# Altium schematic sheet SolenoidSwitching.SchDoc
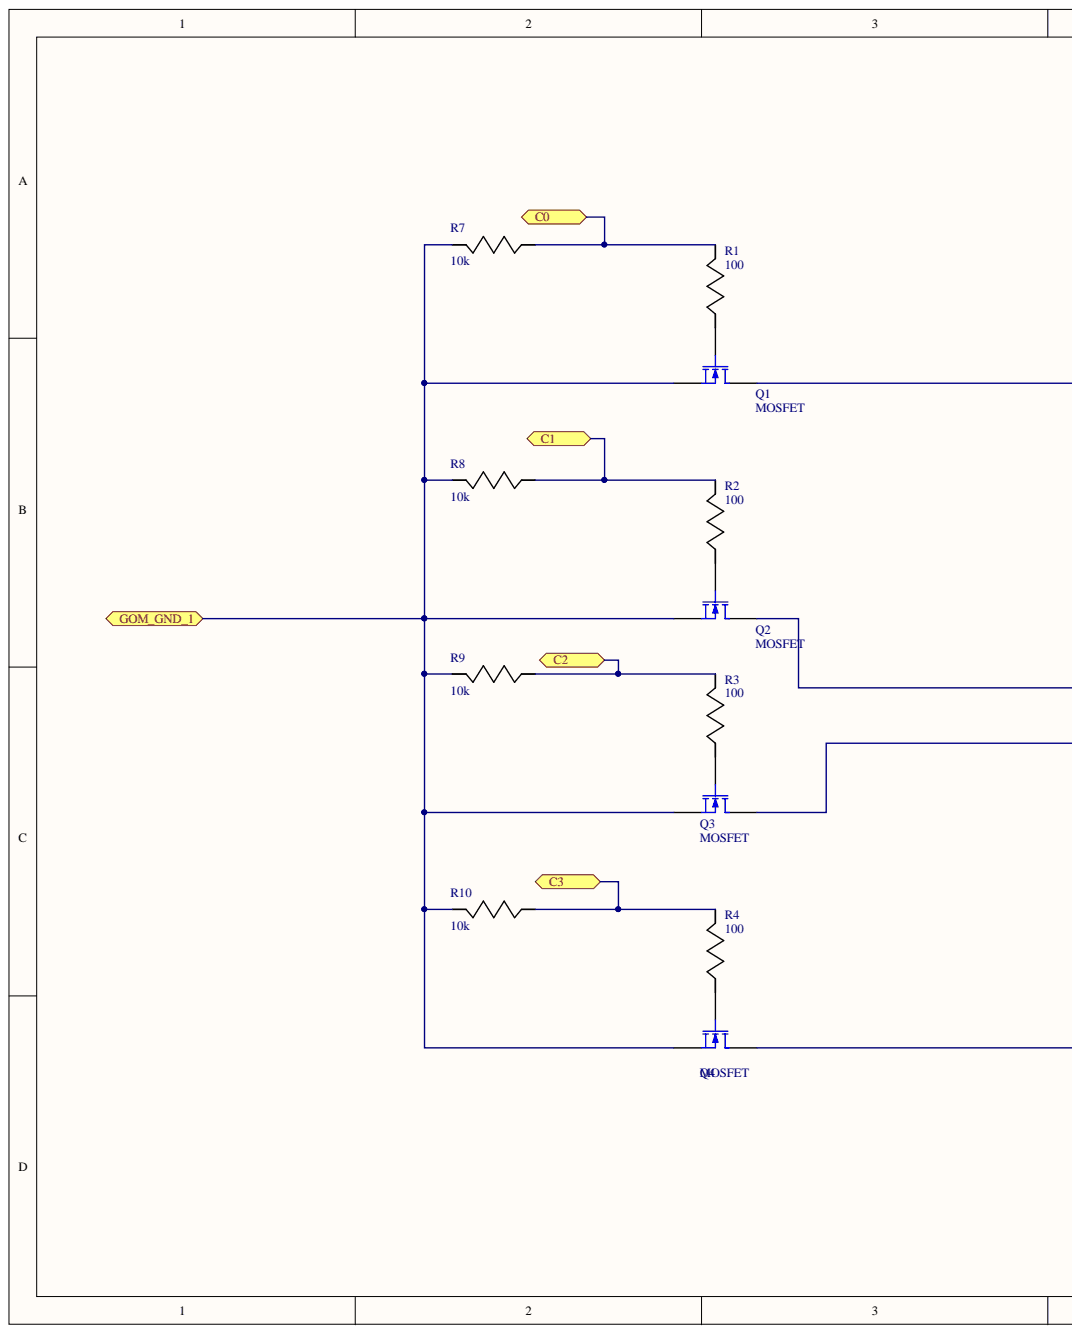
[diagram p0: SolenoidSwitching.SchDoc, left]
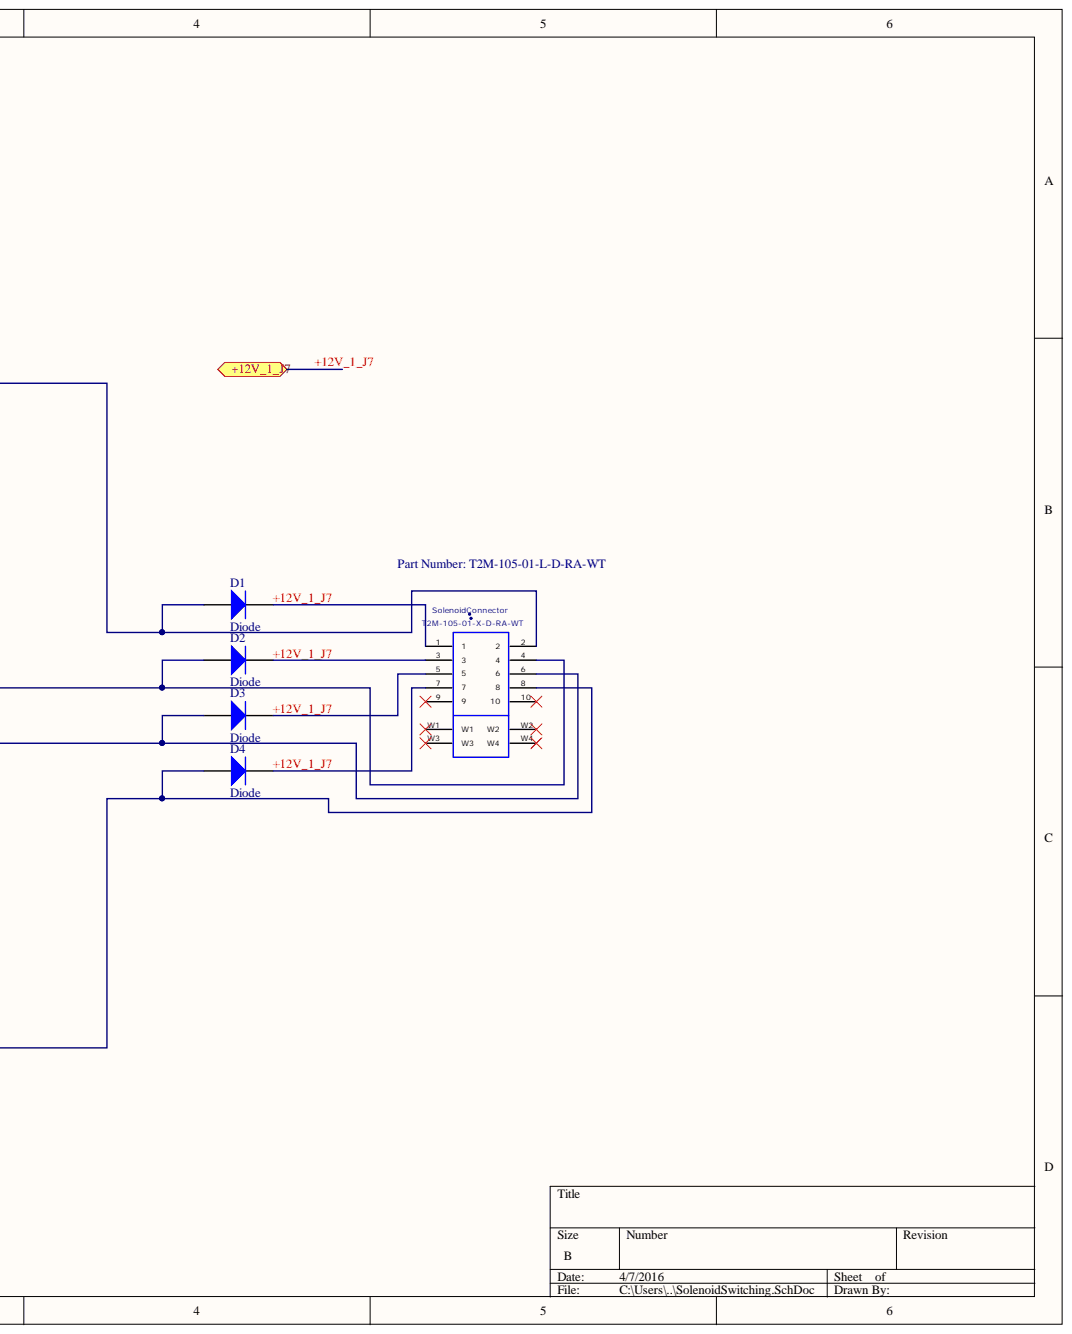
[diagram p1: SolenoidSwitching.SchDoc, right]

## Parts
D1  Diode  [SMC]
D2  Diode  [SMC]
D3  Diode  [SMC]
D4  Diode  [SMC]
Q1  MOSFET  [TO-226-AA]
Q2  MOSFET  [TO-226-AA]
Q3  MOSFET  [TO-226-AA]
Q4  MOSFET  [TO-226-AA]
R1  100  [AXIAL-0.3]
R2  100  [AXIAL-0.3]
R3  100  [AXIAL-0.3]
R4  100  [AXIAL-0.3]
R7  10k  [AXIAL-0.3]
R8  10k  [AXIAL-0.3]
R9  10k  [AXIAL-0.3]
R10  10k  [AXIAL-0.3]
SolenoidConnector  T2M-105-01-X-D-RA-WT  [T2M-105-01-X-D-RA-WT]

## Ports
+12V_1_J7, C0, C1, C2, C3, GOM_GND_1

## Nets
+12V_1_J7: D1.2 D2.2 D3.2 D4.2 SolenoidConnector.1 SolenoidConnector.3 SolenoidConnector.5 SolenoidConnector.7 ; port +12V_1_J7
C0: R1.1 R7.2 ; port C0
C1: R2.1 R8.2 ; port C1
C2: R3.1 R9.2 ; port C2
C3: R4.1 R10.2 ; port C3
GOM_GND_1: Q1.3 Q2.3 Q3.3 Q4.3 R7.1 R8.1 R9.1 R10.1 ; port GOM_GND_1
NetD1_1: D1.1 Q1.1 SolenoidConnector.2
NetD2_1: D2.1 Q2.1 SolenoidConnector.4
NetD3_1: D3.1 Q3.1 SolenoidConnector.6
NetD4_1: D4.1 Q4.1 SolenoidConnector.8
NetQ1_2: Q1.2 R1.2
NetQ2_2: Q2.2 R2.2
NetQ3_2: Q3.2 R3.2
NetQ4_2: Q4.2 R4.2

Not connected: SolenoidConnector 9 10 W1 W2 W3 W4

## Library data of the parts
D1-D4 = Diode: Default Diode; Code_JEDEC: DO-214-AB; Component Kind: Standard; LatestRevisionDate: 17-Jul-2002; LatestRevisionNote: Re-released for DXP Platform.; Library Name: Miscellaneous Devices.IntLib; PackageDescription: DO-214-AB/SMC; 2 C-Bend Leads; Body 7.9 x 5.9 mm, inc. leads (LxW); PackageReference: SMC; PackageVersion: Sep-1996; Published: 8-Jun-2000; Signal Integrity: Diode; Simulation: DIODE; Publisher: Altium Limited
Q1-Q4 = MOSFET-N: N-Channel MOSFET; Component Kind: Standard; LatestRevisionDate: 17-Jul-2002; LatestRevisionNote: Re-released for DXP Platform.; Library Name: Miscellaneous Devices.IntLib; PackageDescription: TO, Flat Index; 3 In-Line, Axial Leads; Body Dia. 4.6mm; Lead Dia. 0.5 mm (max); PackageReference: E3; PackageVersion: 1995/1996; Published: 8-Jun-2000; Simulation: NMOS; Publisher: Altium Limited
R1-R4 R7-R10 = PMR100HZPFU6L00: Imported; Manufacturer_Name: Rohm; Value: 6m; Component Kind: Standard; Library Name: 2016-03-26_18-23-46.SchLib; Manufacturer_Part_Number: PMR100HZPFU6L00; RefDes: RefDes; TYPE: TYPE; Vendor: Rohm
SolenoidConnector = T2M-105-01-X-D-RA-WT: 2MM PITCH SHROUDED RIGHT ANGLE TERMINAL STRIP.; MANUFACTURER: SAMTEC; Component Kind: Standard; Library Name: T2M-105-01-X-D-RA-WT.SCHLIB; PCB DECAL: T2M-105-01-X-D-RA-WT; CREATED: September 24, 2014 - Wendy Xin
